# Altium schematic sheet power.SchDoc(power)
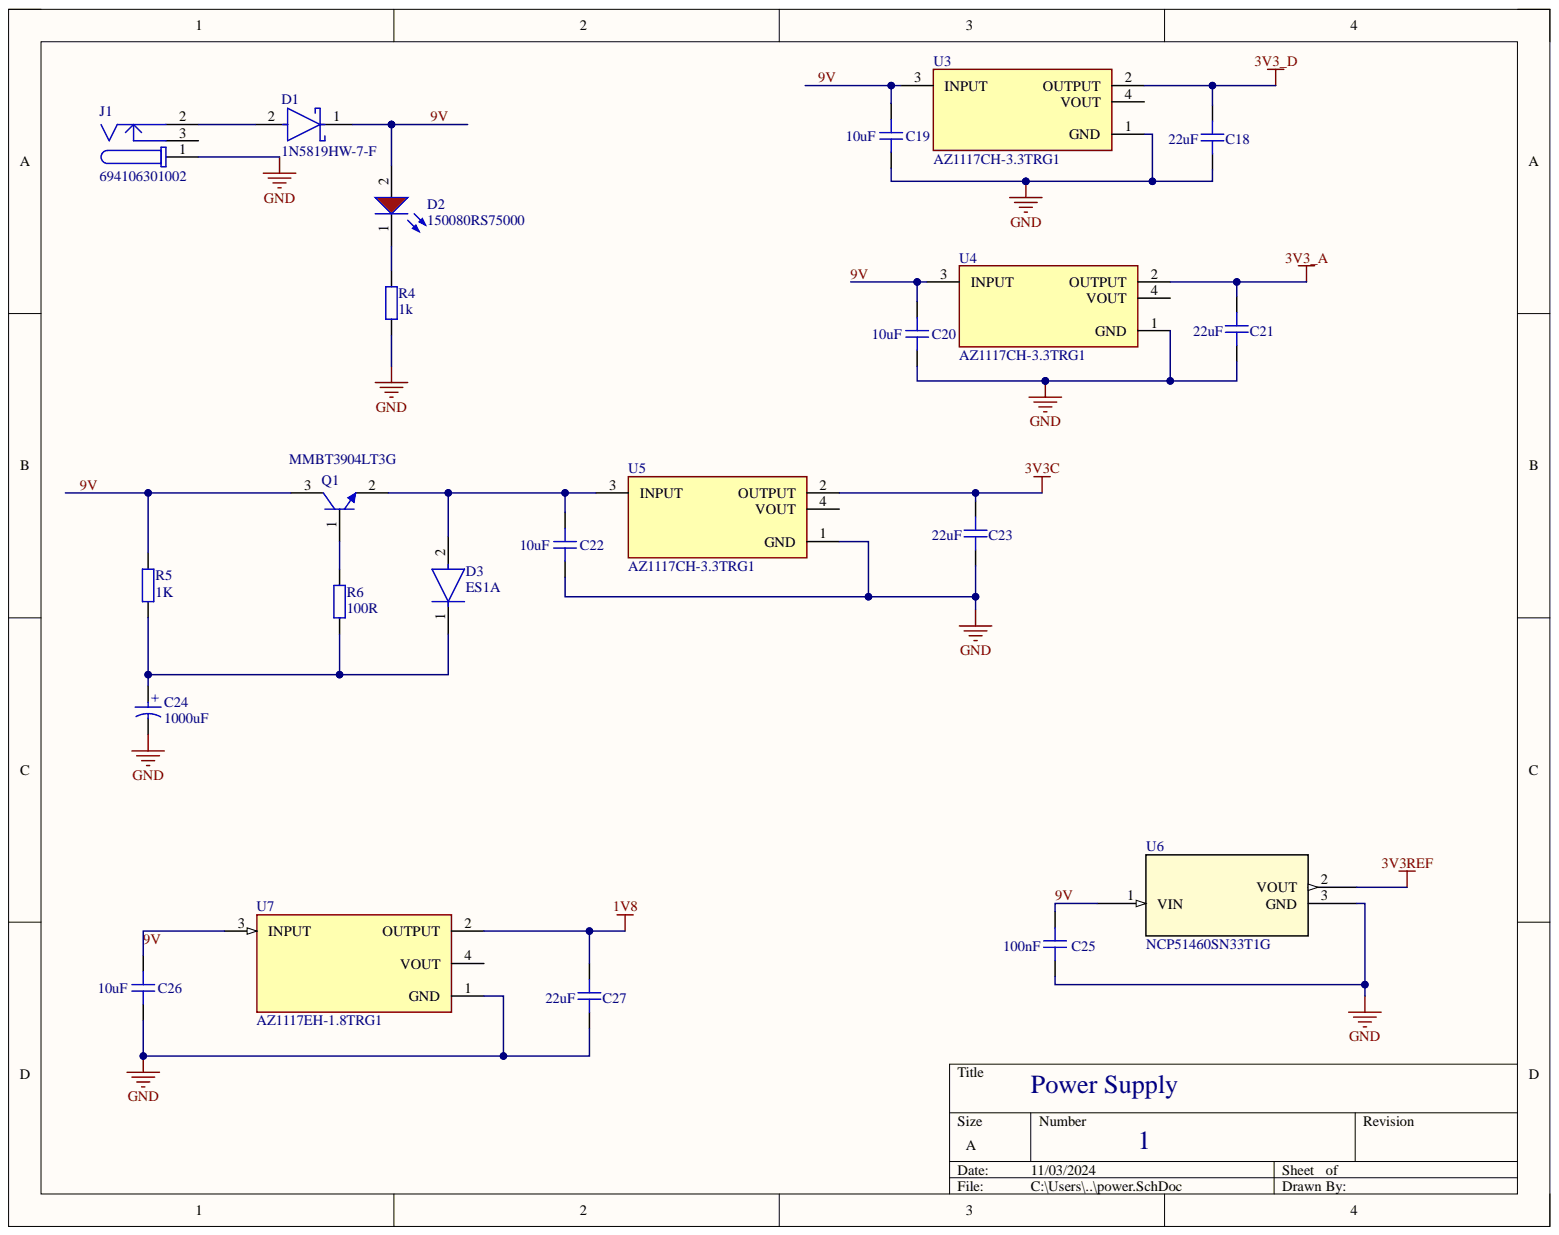
[diagram p0: power.SchDoc]

## Parts
C18  22uF  [CAP_0805]
C19  10uF  [CAP_0805]
C20  10uF  [CAP_0805]
C21  22uF  [CAP_0805]
C22  10uF  [CAP_0805]
C23  22uF  [CAP_0805]
C24  1000uF  [WCAP-ATG5_D10H12.5]
C25  100nF  [CAP_0805]
C26  10uF  [CAP_0805]
C27  22uF  [CAP_0805]
D1  1N5819HW-7-F  [FP-SOD123-IPC_A]
D2  150080RS75000  [WL-SMCW_0805_150080xx75000]
D3  ES1A  [FP-403AE-MFG]
J1  694106301002  [694106301002]
Q1  MMBT3904LT3G  [ONSC-SOT-23-3-318-08_V]
R4  1k  [RES_0805]
R5  1K  [RES_0805]
R6  100R  [RES_0805]
U3  AZ1117CH-3.3TRG1  [FP-SOT223-MFG]
U4  AZ1117CH-3.3TRG1  [FP-SOT223-MFG]
U5  AZ1117CH-3.3TRG1  [FP-SOT223-MFG]
U6  NCP51460SN33T1G  [SOT-23_ONS]
U7  AZ1117EH-1.8TRG1  [FP-SOT223-MFG]

## Nets
1V8: C27.2 U7.2(OUTPUT)
3V3_A: C21.2 U4.2(OUTPUT)
3V3_D: C18.2 U3.2(OUTPUT)
3V3C: C23.2 U5.2(OUTPUT)
3V3REF: U6.2
GND: C18.1 C19.1 C20.1 C21.1 C22.1 C23.1 C24.2 C25.1 C26.1 C27.1 J1.1 R4.1 U3.1(GND) U4.1(GND) U5.1(GND) U6.3 U7.1(GND)
9V: C19.2 C20.2 C25.2 C26.2 D1.1 D2.2 Q1.3 R5.2 U3.3(INPUT) U4.3(INPUT) U6.1 U7.3(INPUT)
NetC22_2: C22.2 D3.2 Q1.2 U5.3(INPUT)
NetC24_1: C24.1 D3.1 R5.1 R6.1
NetD1_2: D1.2 J1.2
NetD2_1: D2.1 R4.2
NetQ1_1: Q1.1 R6.2

Not connected: J1 3; U3 4(VOUT); U4 4(VOUT); U5 4(VOUT); U7 4(VOUT)

## Library data of the parts
C18-C23 C25-C27 = CMP-001-00086-1: Capacitor SMD 0805; Component Kind: Standard; Design Item ID: CAP_0805; Library Name: Projects; Source: Schematic-Lib-PhilsLab.SchLib
C24 = CMP-03913-53914148-1: 860020275015 WCAP-ATG5 Aluminum Electrolytic Capacitors; Capacitance: 1mF; Leakage Current: 100µA; Pitch: 5mm; Ripple Current: 520mA; Voltage Rating: 10V; Component Kind: Standard; Diameter: 10mm; Length: 12.5mm; Library Name: Altium Content Vault; NexarPartId: 53914148; RoHS: Non-Compliant
D1 = CMP-0303-00003-3: DIODE SCHOTTKY 40V 1A SOD123; Capacitance: 110pF; Element Configuration: Single; Forward Voltage: 450mV; Max Forward Surge Current (Ifsm): 25A; Max Power Dissipation: 450mW; Peak Reverse Current: 50uA; Polarity: Standard; Average Rectified Current: 1A; Case/Package: SOD-123-2; Component Kind: Standard; Contact Plating: Tin; Current Rating: 1A; Forward Current: 1A; Height: 1.25mm; Lead Free: Lead Free; Length: 2.85mm; Library Name: Altium Content Vault; Max Operating Temperature: 125°C; Max Repetitive Reverse Voltage (Vrrm): 40V; Max Reverse Voltage (DC): 40V; Min Operating Temperature: -65°C; Number of Pins: 2; Output Current: 1A; Package Quantity: 3000; Packaging: Tape and Reel; Peak Non-Repetitive Surge Current: 25A; Radiation Hardening: No; REACH SVHC: No SVHC; Termination: SMD/SMT; Voltage Rating (DC): 40V; Weight: 0.000353oz; Width: 1.7mm
D2 = CMP-1426-00011-3: LED Uni-Color Red 630nm 2-Pin Chip 0805(2012Metric) T/R; Dominant Wavelength: 625nm; Forward Current: 100mA; Forward Voltage: 2V; Lens Style: Clear, Rectangular; Luminous Intensity: 150mcd; Max Junction Temperature (Tj): 95°C; Max Reverse Voltage (DC): 5V; Power Dissipation: 72mW; Test Current: 20mA; Viewing Angle: 140°; Case Code (Imperial): 0805; Case Code (Metric): 2012; Case/Package: 0805; Color: Red; Component Kind: Standard; Height: 700µm; Length: 2mm; Library Name: Altium Content Vault; Max Operating Temperature: 85°C; Min Operating Temperature: -40°C; Mount: Surface Mount; Packaging: Tape and Reel; Peak Wavelength: 630nm; REACH SVHC: Unknown; RoHS: Compliant; Wavelength: 625nm; Width: 1.25mm
D3 = CMP-07163-000019-1: DIODE GEN PURP 50V 1A SMA; Manufacturer: ON Semiconductor; Capacitance: 7pF; Max Junction Temp: +150°C; Max Surge Forward Current: 30A; Peak Reverse Current: 5uA; Standoff Height: 0.05mm; Value: 7pF; Automotive: No; Category: Diode; Component Kind: Standard; ComponentLink2Description: Datasheet; ComponentLink2URL: https://www.onsemi.com/pub/Collateral/ES1D-D.PDF; Device Class L1: Discrete Semiconductors; Device Class L2: Diodes; Device Class L3: Rectifier Diodes; Digikey Description: DIODE GEN PURP 50V 1A SMA; Footprint Url: https://www.onsemi.com/pub/Collateral/403AE.PDF; Forward Current: 1A; Height: 2.403mm; Lead Free: Yes; Library Name: Altium Content Vault; Manufacturer Part Number: ES1A; Max Repetitive Reverse Voltage: 50V; Mouser Description: ES1A Series 50 V 1 A Surface Mount Fast Rectifier - DO-214AC; Octopart: https://octopart.com/es1a-on+semiconductor-84324851; Package: 403AE; RoHS: TRUE; Temperature: -50°C to +150°C; Temperature Range High: +150°C; Temperature Range Low: -50°C
J1 = CMP-1502-00188-2: NexarPartId: 52676100; Connector Type: Power; Current Rating: 5A; Gender: Male; Insulation Resistance: 100MΩ; Number of Contacts: 3; Operating Supply Voltage: 24V; Shielding: Unshielded; Voltage Rating (DC): 20V; Color: Black; Component Kind: Standard; Contact Gender: Female; Contact Material: Gold, Tin; Contact Plating: Tin; Flammability Rating: UL94 V-0; Height: 11mm; Housing Material: Polyamide; Library Name: Altium Content Vault; Max Operating Temperature: 85°C; Min Operating Temperature: -40°C; Mount: Through Hole; Packaging: Bulk; REACH SVHC: Unknown; RoHS: Compliant; Termination: Through Hole; Voltage Rating: 24V
Q1 = CMP-1048-00630-1: General Purpose Transistor, NPN Silicon, 3-Pin SOT-23, Pb-Free, Tape and Reel; Manufacturer: On Semiconductor; fT Min (MHz): 300; IC Continuous (A): 0.2; Component Kind: Standard; ComponentLink1Description: Manufacturer URL; ComponentLink1URL: http://www.onsemi.com/; ComponentLink2Description: Datasheet; ComponentLink2URL: http://www.onsemi.cn/pub_link/Collateral/MMBT3904LT1-D.PDF; ComponentLink3Description: Package Specification; ComponentLink3URL: http://www.onsemi.cn/pub_link/Collateral/318-08.PDF; DatasheetVersion: Rev. 12; Library Name: Altium Content Vault; Mounting Technology: Surface Mount; PackageDescription: 3-Pin Small Outline Transistor, Body 2.92 x 1.3 mm, Pitch 0.95 mm; PackageReference: SOT-23-3-318-08; PackageVersion: Rev. AP, 11/2009; Packing: Tape and Reel; PartNumber: MMBT3904LT3G; Polarity: NPN; RoHS: TRUE
R4-R6 = CMP-009-00180-1: Resistor SMD 0805; Component Kind: Standard; Design Item ID: RES_0805; Library Name: Projects; Source: Schematic-Lib-PhilsLab.SchLib
U3-U5 = CMP-12756-000028-1: IC REG LINEAR 3.3V 800MA SOT223; Accuracy: 1%; Dropout Voltage: 1.2V; Max Input Voltage: 15V; Max Output Current: 1.35A; Min Current Limit: 1A; Number of Outputs: 1; Output Type: Fixed; Polarity: Positive; Power Supply Rejection Ratio (PSRR): 70dB; Quiescent Current: 6mA; Reference Voltage: 1.262V; Case/Package: SOT-223; Component Kind: Standard; Height: 1.7mm; Lead Free: Lead Free; Length: 6.7mm; Library Name: Altium Content Vault; Max Operating Temperature: 125°C; Min Operating Temperature: -20°C; Mount: Surface Mount; Number of Pins: 4; Number of Regulators: 1; Output Current: 800mA; Output Voltage: 3.3V; Package Quantity: 4000; Packaging: Tape and Reel; Width: 3.7mm
U6 = NCP51460SN33T1G: No Description Available; Mfr_Name: onsemi; Component Kind: Standard; Library Name: NCP51460SN33T1G.IntLib; RefDes: RefDes; Type: Type
U7 = CMP-12756-000038-1: IC REG LINEAR 1.8V 1A SOT223; Dropout Voltage: 1.1V; Max Input Voltage: 13V; Number of Outputs: 1; Operating Supply Current: 3.5mA; Output Type: Fixed; Power Supply Rejection Ratio (PSRR): 70dB; Case/Package: TO-261-4; Component Kind: Standard; Library Name: Altium Content Vault; Max Operating Temperature: 125°C; Min Operating Temperature: -40°C; Mount: Surface Mount; Number of Regulators: 1; Output Current: 1A; Output Voltage: 1.8V; Package Quantity: 4000; Packaging: Tape and Reel; Weight: 0.000282oz
